# Altium schematic sheet RF.SchDoc
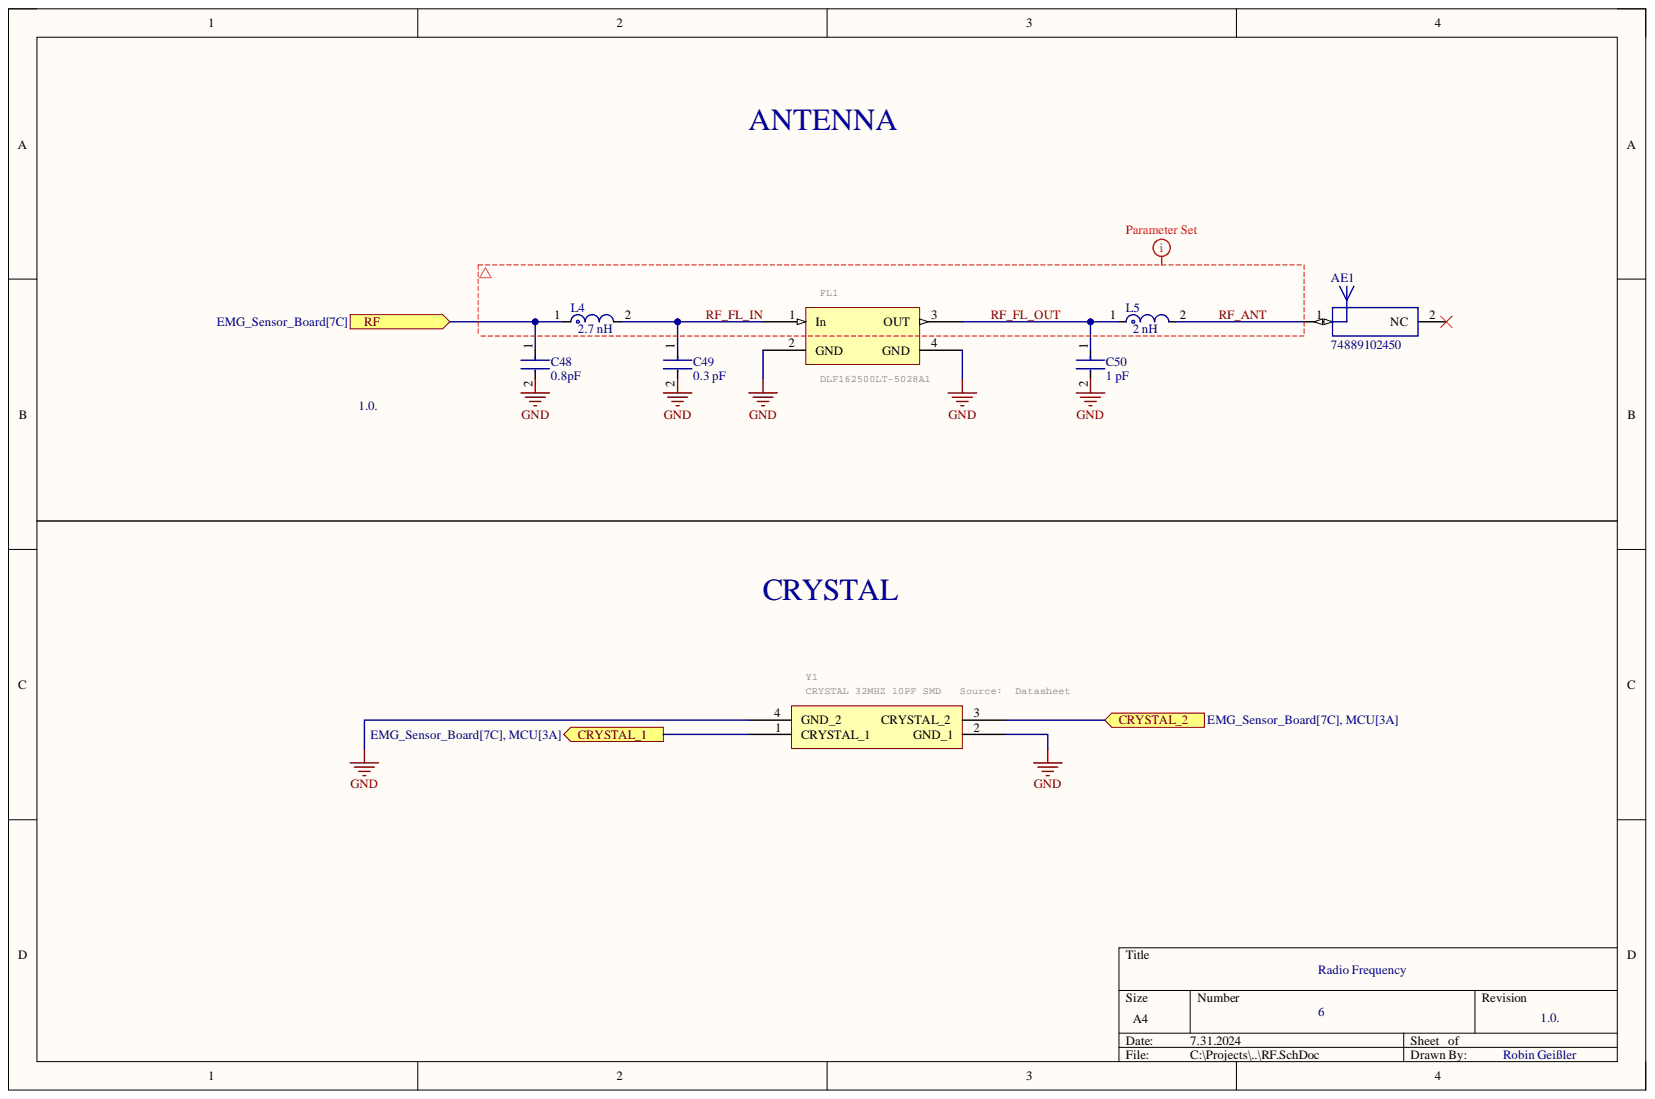
[diagram p0: RF.SchDoc]

## Parts
AE1  74889102450  [74889102450]
C48  0.8pF  [FP-GCM188-0_1-IPC_C]
C49  0.3 pF  [FP-GQM187-0_15-IPC_A]
C50  1 pF  [FP-C0603C-CF-MFG]
FL1  DLF162500LT-5028A1  [DLF162500LT5028A1]
L4  2.7 nH  [FP-LQG15HH_02-IPC_C]
L5  2 nH  [FP-LQP03TG_02-IPC_A]
Y1  CRYSTAL 32MHZ 10PF SMD   Source:  Datasheet  [NX2016SA32MEXS00ACS06465]

## Ports
CRYSTAL_1, CRYSTAL_2, RF

## Nets
GND: C48.2 C49.2 C50.2 FL1.2(GND) FL1.4(GND) Y1.2(GND_1) Y1.4(GND_2)
CRYSTAL_1: Y1.1(CRYSTAL_1) ; port CRYSTAL_1
CRYSTAL_2: Y1.3(CRYSTAL_2) ; port CRYSTAL_2
RF: C48.1 L4.1 ; port RF
RF_ANT: AE1.1 L5.2
RF_FL_IN: C49.1 FL1.1(In) L4.2
RF_FL_OUT: C50.1 FL1.3(OUT) L5.1

Not connected: AE1 2

## Library data of the parts
AE1 = CMP-03913-126098686-1: 74889102450 Chip Antenna, 2.45Ghz, 9Mm X 2Mm X 1Mm Rohs Compliant: Yes; Current Rating: 2A; Impedance: 50Ω; Component Kind: Standard; Height: 1.01mm; Length: 9mm; Library Name: Altium Content Vault; Max Operating Temperature: 85°C; Min Operating Temperature: -40°C; NexarPartId: 126098686; RoHS: Compliant; Width: 2mm
C48 = CMP-06035-009191-1: Ceramic Capacitor for Automotive 0.8pF  ±0.1pF 100VDC C0G 0603 Paper T/R; Component Kind: Standard; Library Name: Altium Content Vault
C49 = CMP-06035-023113-1: Ceramic Capacitor 0.3pF ±0.05pF 250VDC C0G 0603 Paper T/R; Component Kind: Standard; Library Name: Altium Content Vault
C50 = CMP-2006-03328-2: CAP CER 1PF 50V C0G/NP0 0603; Manufacturer: KEMET; Manufacturer Part Number: C0603C109C5GACTU; Automotive Grade: Grade 1; Capacitance: 1.0 pF; Material: Ceramic; Temperature Characteristic: C0G; Temperature Coefficient: 30ppm/°C; Tolerance: 0.25 pF; Value: 1.0 pF; Automotive: Yes; Category: Cap; Component Kind: Standard; ComponentLink2Description: Datasheet; ComponentLink2URL: https://api.kemet.com/component-edge/download/datasheet/C0603C100J5GACAUTO.pdf; Device Class L1: Passive Components; Device Class L2: Capacitors; Device Class L3: Ceramic Capacitors; Digikey Description: CAP CER 1PF 50V C0G/NP0 0603; Height: 0.87mm; Lead Free: Yes; Library Name: Altium Content Vault; Mouser Description: Multilayer Ceramic Capacitors MLCC - SMD/SMT 50volts 1.0pF C0G 0.25%; Octopart: https://octopart.com/c0603c109c5gactu-kemet-107248; Package: C0603C-CF; RoHS: TRUE; Temperature: -55°C to +125°C; Temperature Range High: +125°C; Temperature Range Low: -55°C; Voltage Rating: 50 V
FL1 = DLF162500LT-5028A1: Signal Conditioning LTCC LOW PASS FLTR 2400-2500MHz   Source:  Datasheet; Manufacturer_Name: TDK; Arrow_Part_Number: DLF162500LT-5028A1; Arrow_Price-Stock: https://www.arrow.com/en/products/dlf162500lt-5028a1/tdk?region=nac; Component Kind: Standard; Height: 0.4mm; Manufacturer_Part_Number: DLF162500LT-5028A1; Mouser_Part_Number: 810-DLF16250LT5028A1; Mouser_Price-Stock: https://www.mouser.co.uk/ProductDetail/TDK/DLF162500LT-5028A1?qs=U%2FZX79kHR%2FlwIbAISCs3qA%3D%3D; Library Name: EMG_Sensor.SchLib
L4 = CMP-2000-06580-2: Multilayer type RF Inductor 2.7nH ±0.3nH 0.12Ω 800mA 0402; Core Material: Air; Current Rating: 300mA; DC Resistance (DCR): 150mR; Q Factor: 8; Self Resonant Frequency: 6GHz; Shielding: Unshielded; Test Frequency: 100MHz; Case Code (Imperial): 0402; Case Code (Metric): 1005; Case/Package: 0402; Component Kind: Standard; DC Current: 300mA; Depth: 0.55mm; Height: 0.5mm; Height - Seated (Max): 0.022inch; Inductance: 2.7nH; Lead Free: Lead Free; Length: 1mm; Library Name: Altium Content Vault; Max DC Current: 300mA; Max Operating Temperature: 125°C; Military Standard: Not; Min Operating Temperature: -55°C; Mount: Surface Mount; Package Quantity: 10000; Packaging: Tape and Reel; Radiation Hardening: No; Termination: SMD/SMT; Width: 0.5mm
L5 = CMP-06042-013297-1: Film type RF Inductor 2nH ±0.1nH 600mA 0.15Ω 0201 (0603); Core Material: Non-Magnetic; Current: 400mA; DC Resistance (DCR): 150mR; Q Factor: 14; Self Resonant Frequency: 12.5GHz; Shielding: Unshielded; Test Frequency: 500MHz; Case Code (Imperial): 0201; Case Code (Metric): 0603; Case/Package: 0201; Component Kind: Standard; Current Rating: 600mA; DC Current: 600mA; Depth: 0.33mm; Height: 0.3mm; Height - Seated (Max): 0.013inch; Inductance: 2nH; Length: 0.6mm; Library Name: Altium Content Vault; Max DC Current: 600mA; Max Operating Temperature: 125°C; Military Standard: Not; Min Operating Temperature: -55°C; Mount: Surface Mount; Package Quantity: 15000; Packaging: Tape and Reel; REACH SVHC: No SVHC; Resistor Type: Thin Film; Termination: SMD/SMT; Width: 0.012inch
Y1 = NX2016SA-32M-EXS00A-CS06465: Manufacturer_Name: NDK; GateName_1: G$1; Component Kind: Standard; DeviceSetName: NX2016SA-32M-EXS00A-CS06465; Height: 0.5mm; LibraryName: NX2016SA-32M-EXS00A-CS06465; Manufacturer_Part_Number: NX2016SA-32M-EXS00A-CS06465; Mouser_Part_Number: 344-NX2016SA32S06465; Mouser_Price-Stock: https://www.mouser.co.uk/ProductDetail/NDK/NX2016SA-32M-EXS00A-CS06465?qs=w%2Fv1CP2dgqr82b0JjRnv4A%3D%3D; Package: NX2016SA32MEXS00ACS06465; SymbolName_1: NX2016SA-32M-EXS00A-CS06465; Library Name: EMG_Sensor.SchLib
